# Altium schematic sheet cwh_pll.SchDoc
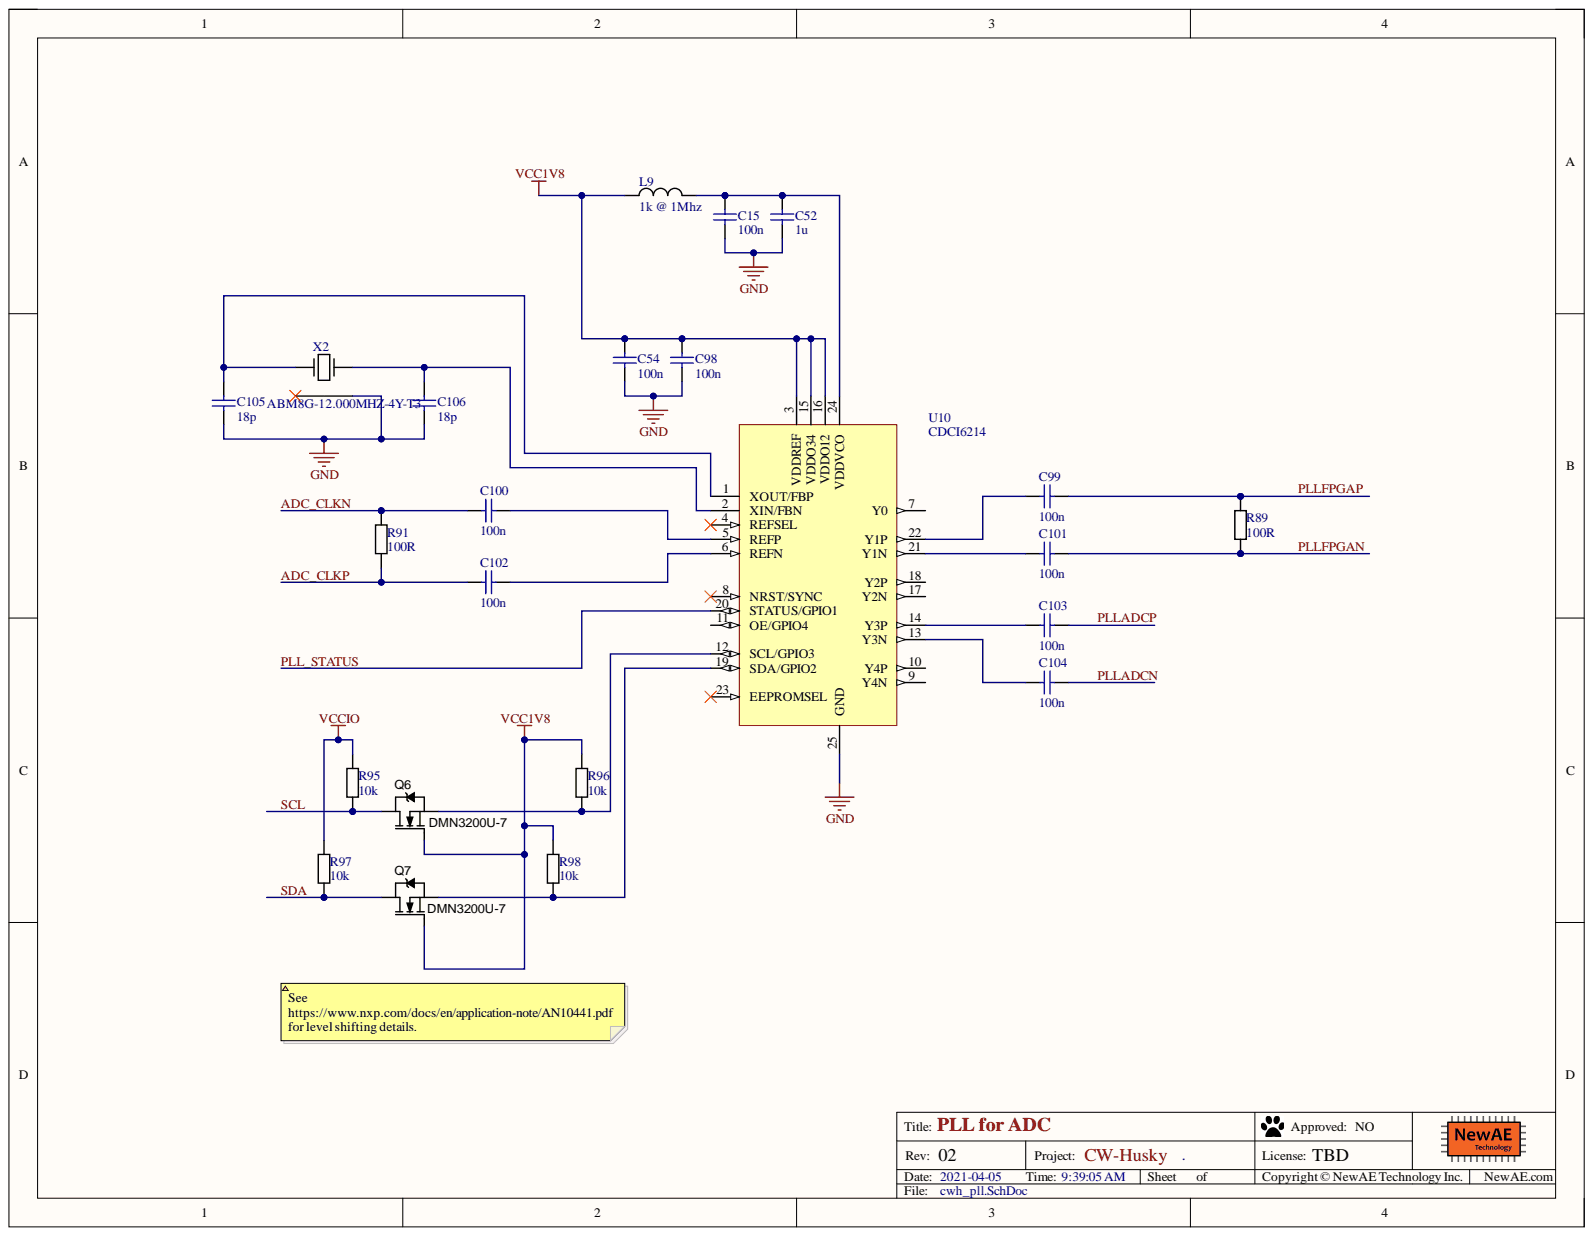
[diagram p0: cwh_pll.SchDoc]

## Parts
C15  100n  [0402]
C52  1u  [0603]
C54  100n  [0402]
C98  100n  [0402]
C99  100n  [0402]
C100  100n  [0402]
C101  100n  [0402]
C102  100n  [0402]
C103  100n  [0402]
C104  100n  [0402]
C105  18p  [0402]
C106  18p  [0402]
L9  1k @ 1Mhz  [0603]
Q6  DMN3200U-7  [SOT23]
Q7  DMN3200U-7  [SOT23]
R89  100R  [0402]
R91  100R  [0402]
R95  10k  [0402]
R96  10k  [0402]
R97  10k  [0402]
R98  10k  [0402]
U10  CDCI6214  [QFN24]
X2  ABM8G-12.000MHZ-4Y-T3  [ABM8G]

## Nets
GND: C15.2 C52.2 C54.2 C98.2 C105.2 C106.2 U10.25(GND) X2.2
VCC1V8: C54.1 C98.1 L9.1 Q6.1 Q7.1 R96.2 R98.2 U10.3(VDDREF) U10.15(VDDO34) U10.16(VDDO12)
VCCIO: R95.2 R97.2
ADC_CLKN: C100.2 R91.1
ADC_CLKP: C102.2 R91.2
PLL_STATUS: U10.20(STATUS/GPIO1)
PLLADCN: C104.1
PLLADCP: C103.1
PLLFPGAN: C101.1 R89.2
PLLFPGAP: C99.1 R89.1
SCL: Q6.3 R95.1
SDA: Q7.3 R97.1
NetC15_1: C15.1 C52.1 L9.2 U10.24(VDDVCO)
NetC99_2: C99.2 U10.22(Y1P)
NetC100_1: C100.1 U10.5(REFP)
NetC101_2: C101.2 U10.21(Y1N)
NetC102_1: C102.1 U10.6(REFN)
NetC103_2: C103.2 U10.14(Y3P)
NetC104_2: C104.2 U10.13(Y3N)
NetC105_1: C105.1 U10.1(XOUT/FBP) X2.3
NetC106_1: C106.1 U10.2(XIN/FBN) X2.1
NetQ6_2: Q6.2 R96.1 U10.12(SCL/GPIO3)
NetQ7_2: Q7.2 R98.1 U10.19(SDA/GPIO2)

Not connected: U10 4(REFSEL) 7(Y0) 8(NRST/SYNC) 9(Y4N) 10(Y4P) 11(OE/GPIO4) 17(Y2N) 18(Y2P) 23(EEPROMSEL); X2 4

## Library data of the parts
C15 C54 C98-C104 = CCHIP-100n-0402: 100n, 0402; Manufacture Part Number 1: CGA2B3X7R1V104K050BB; Component Group: Capacitor; Database Table Name: main; Case-EIA: 0402; Component Kind: Standard; Component Type: Standard; Library Name: NewAE_AltiumLib.DBLib; Mounting Technology: SMT; Part Number: CCHIP-100n-0402; Pin Count: 2; Supplier 1: Digi-Key; Supplier Part Number 1: 445-6901-1-ND; Value: 100n
C52 = CCHIP-1u-0603: 1u, 0603, 50V; Manufacture Part Number 1: CL10A105KB8NNNC; Case-Metric: 1608; Component Group: Capacitor; Database Table Name: main; Rated Voltage: 50VDC; Case-EIA: 0603; Component Kind: Standard; Component Type: Standard; Library Name: NewAE_AltiumLib.DBLib; Mounting Technology: SMT; Part Number: CCHIP-1u-0603; Pin Count: 2; Supplier 1: Digi-Key; Supplier Part Number 1: 1276-1860-1-ND; Value: 1u
C105 C106 = CCHIP-18p-0402: 18p, 0402; Manufacture Part Number 1: GRM1555C1H180JA01D; Component Group: Capacitor; Database Table Name: main; Case-EIA: 0402; Component Kind: Standard; Component Type: Standard; Library Name: NewAE_AltiumLib.DBLib; Mounting Technology: SMT; Part Number: CCHIP-18p-0402; Pin Count: 2; Supplier 1: Digi-Key; Supplier Part Number 1: 490-5858-1-ND; Value: 18p
L9 = FBCHIP-1k-0603: Ferrite Beads HI CUR CHIP BD 0603 1000OHMS 25%; Manufacture 1: Taiyo Yuden; Manufacture Part Number 1: FBMH1608HM102-T FBMH1608HM102-T FBMH1608HM102-T FBMH1608HM102-T; Component Group: Inductor; Database Table Name: main; PP Material Stack: 0; Component Kind: Standard; Component Type: Standard; Library Name: NewAE_AltiumLib.DBLib; Mounting Technology: SMT; Part Number: FBCHIP-1k-0603; Pin Count: 2; PP Rotation: 0; RoHS: Yes; Supplier 1: Mouser; Supplier Part Number 1: 963-FBMH1608HM102-T
Q6 Q7 = DMN3200U-7: MOSFET N-CH 30V 2.2A SOT23-3; Manufacture 1: Diodes Inc.; Database Table Name: main; PP Material Stack: 0; Rated Power: 3A; Component Group: MOSFET; Component Kind: Standard; Component Type: Standard; Library Name: NewAE_AltiumLib.DBLib; Manufacture Part Number 1: DMN3200U-7; Mounting Technology: SMT; Part Number: DMN3200U-7; Pin Count: 3; PP Rotation: 0; Rated Voltage: 30V; RoHS: Yes; Supplier 1: Digi-Key; Supplier Part Number 1: DMN3200U-7DICT-ND
R89 R91 = RCHIP-100R-0402: 100R res, 0402, 1%, 1/16W; Manufacture 1: Yageo; Manufacture Part Number 1: RC0402FR-07100RL; Case-Metric: 1005; Component Group: Resistor; Database Table Name: main; Rated Power: 0.063W; Rated Voltage: 75VDC; Case-EIA: 0402; Component Kind: Standard; Component Type: Standard; Library Name: NewAE_AltiumLib.DBLib; Mounting Technology: SMT; Part Number: RCHIP-100R-0402; Pin Count: 2; Supplier 1: Digi-Key; Supplier Part Number 1: 311-100LRCT-ND; Temperature Range: -55C to 155C; Tolerance: 1%; Value: 100R
R95-R98 = RCHIP-10K-0402: 10k res, 0402, 1%, 1/16W; Manufacture 1: Yageo; Manufacture Part Number 1: RC0402FR-0710KL; Case-Metric: 1005; Component Group: Resistor; Database Table Name: main; Rated Power: 0.063W; Rated Voltage: 75VDC; Case-EIA: 0402; Component Kind: Standard; Component Type: Standard; Library Name: NewAE_AltiumLib.DBLib; Mounting Technology: SMT; Part Number: RCHIP-10K-0402; Pin Count: 2; Supplier 1: Digi-Key; Supplier Part Number 1: 311-10.0KLRCT-ND; Temperature Range: -55C to 155C; Tolerance: 1%; Value: 10k
U10 = CDCI6214RG: PLL Chip, 350 MHz out max, LVDS; Manufacture 1: Texas Instruments; Component Group: Digital IC; Database Table Name: main; PP Material Stack: 0; Component Kind: Standard; Component Type: Standard; Library Name: NewAE_AltiumLib.DBLib; Manufacture Part Number 1: CDCI6214RG; Part Number: CDCI6214RG; Pin Count: 25; PP Rotation: 0; PP Rotation1: 0
X2 = ABM8G-12.000MHZ-4Y-T3: CRYSTAL 12.0000MHZ 10PF SMD; Manufacture 1: Abracon LLC; Database Table Name: main; Component Group: Crystal; Component Kind: Standard; Component Type: Standard; Library Name: NewAE_AltiumLib.DBLib; Manufacture Part Number 1: ABM8G-12.000MHZ-4Y-T3; Part Number: ABM8G-12.000MHZ-4Y-T3; Pin Count: 4; Supplier 1: Digi-Key; Supplier Part Number 1: 535-10901-1-ND; Value: 12.000MHz
